# Altium schematic sheet Trottinette_Connexion_PCB.SchDoc(Trottinette_Connexion_PCB)
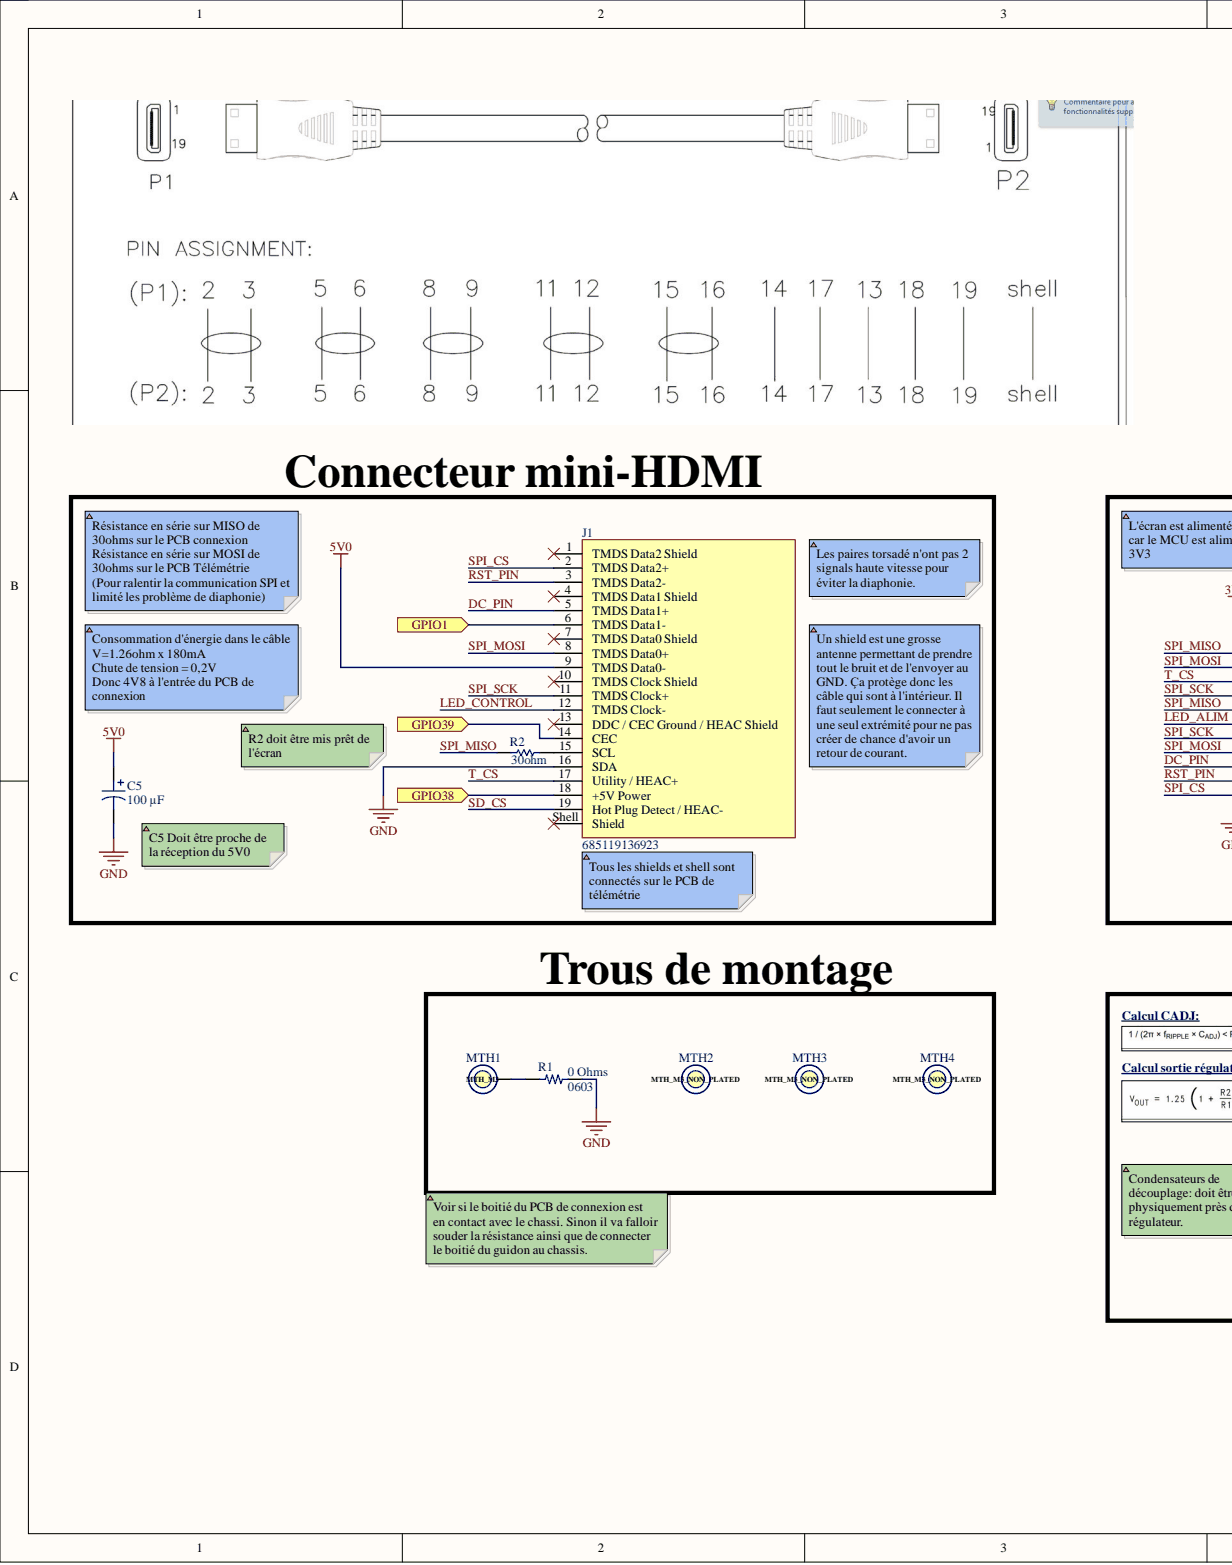
[diagram p0: Trottinette_Connexion_PCB.SchDoc, left]
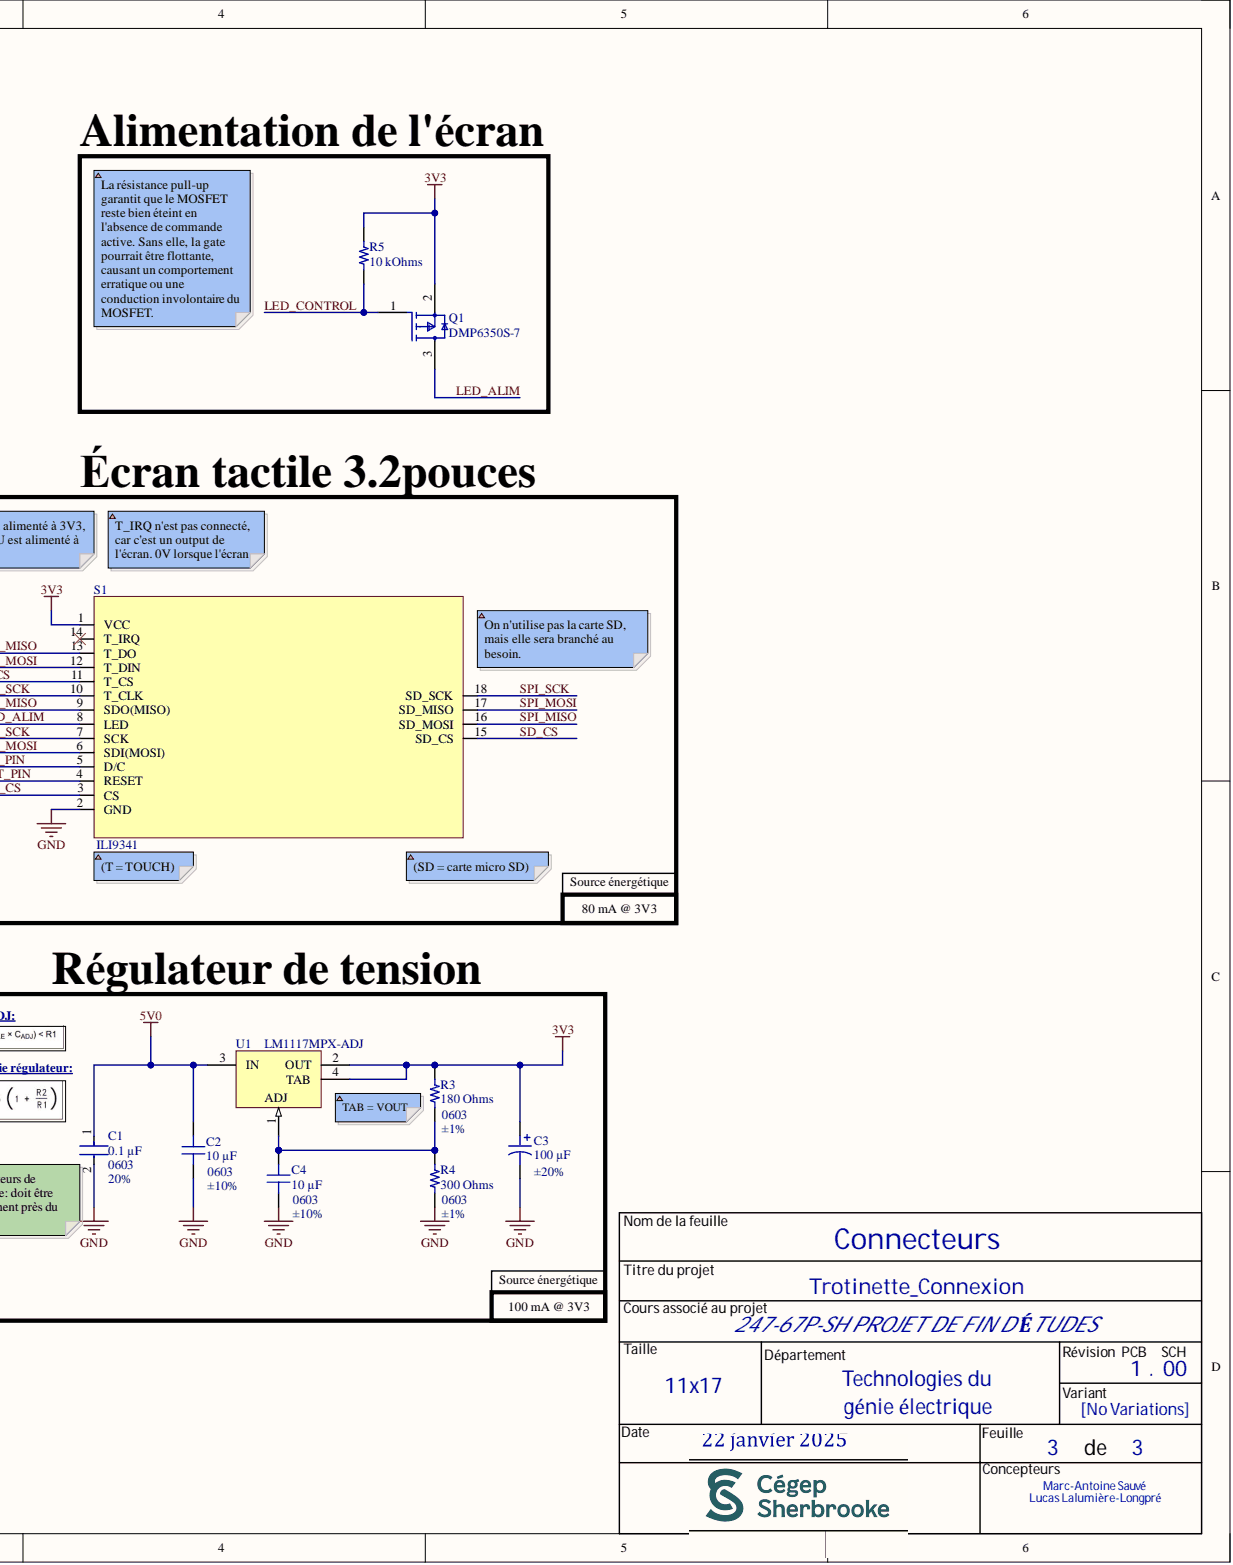
[diagram p1: Trottinette_Connexion_PCB.SchDoc, right]

## Parts
C1  Capacitance: 0.1 µF  Case Code (Imperial): 0603  Tolerance: 20%  [FP-0603-L_1_6_0_15-W_0_81-IPC_B]  (hidden comment: 0603ZC104MAT2A)
C2  10 µF  Device Package: 0603  Tolerance: ±10%  [CAP 0603_1608]
C3  100 µF  Tolerance: ±20%  [CAP ALSMD 6.6MM H6.1MM]
C4  10 µF  Device Package: 0603  Tolerance: ±10%  [CAP 0603_1608]
C5  100 µF  [CAP ALSMD 6.6MM H6.1MM]
J1  685119136923  [685119136923]
MTH1  MTH_M3  [MTH_M3]  (no BOM)
MTH2  MTH_M3_NON_PLATED  [MTH_M3_NON_PLATED]  (no BOM)
MTH3  MTH_M3_NON_PLATED  [MTH_M3_NON_PLATED]  (no BOM)
MTH4  MTH_M3_NON_PLATED  [MTH_M3_NON_PLATED]  (no BOM)
Q1  DMP6350S-7  [FP-SOT23-MFG]
R1  0 Ohms  Supplier Device Package: 0603  [RES 0603_1608]
R2  value 30ohm  [RESC1608X55X30LL15T15]  (hidden comment: ERJ3EKF30R0V)
R3  180 Ohms  Device Package: 0603  Tolerance: ±1%  [RES 0603_1608]
R4  300 Ohms  Supplier Device Package: 0603  Tolerance: ±1%  [RES 0603_1608]
R5  10 kOhms  [RES 0603_1608]
S1  ILI9341  [PCBComponent_1]
U1  LM1117MPX-ADJ  [MP04A_N]

## Ports
GPIO1, GPIO38, GPIO39

## Nets
3V3: C3.1 Q1.2 R3.2 R5.2 S1.1(VCC) U1.2(OUT) U1.4(TAB)
5V0: C1.1 C2.2 C5.1 J1.9(TMDS_Data0-) U1.3(IN)
GND: C1.2 C2.1 C3.2 C4.1 C5.2 J1.16(SDA) R1.2 R4.2 S1.2(GND)
DC_PIN: J1.5(TMDS_Data1+) S1.5(D/C)
GPIO1: J1.6(TMDS_Data1-) ; port GPIO1
GPIO38: J1.18(+5V_Power) ; port GPIO38
GPIO39: J1.14(CEC) ; port GPIO39
LED_ALIM: Q1.3 S1.8(LED)
LED_CONTROL: J1.12(TMDS_Clock-) Q1.1 R5.1
RST_PIN: J1.3(TMDS_Data2-) S1.4(RESET)
SD_CS: J1.19(Hot_Plug_Detect_/_HEAC-) S1.15(SD_CS)
SPI_CS: J1.2(TMDS_Data2+) S1.3(CS)
SPI_MISO: R2.2 S1.9(SDO(MISO)) S1.13(T_DO) S1.16(SD_MOSI)
SPI_MOSI: J1.8(TMDS_Data0+) S1.6(SDI(MOSI)) S1.12(T_DIN) S1.17(SD_MISO)
SPI_SCK: J1.11(TMDS_Clock+) S1.7(SCK) S1.10(T_CLK) S1.18(SD_SCK)
T_CS: J1.17(Utility_/_HEAC+) S1.11(T_CS)
NetC4_2: C4.2 R3.1 R4.1 U1.1
NetJ1_15: J1.15(SCL) R2.1
NetMTH1_1: MTH1.1 R1.1

Not connected: J1 1(TMDS_Data2_Shield) 4(TMDS_Data1_Shield) 7(TMDS_Data0_Shield) 10(TMDS_Clock_Shield) 13(DDC_/_CEC_Ground_/_HEAC_Shield) Shell(Shield); S1 14(T_IRQ)

## Library data of the parts
C1 = CMP-2006-04550-2: General Purpose Ceramic Capacitor, 0603, 100nF, 20%, X7R, 15%, 10V; Case Code (Metric): 1608; Case/Package: 0603; Component Kind: Standard; Construction: Flat; Depth: 0.81mm; Dielectric: X7R; Lead Free: Lead Free; Lead Pitch: 0.014inch; Length: 1.6mm; Library Name: Altium Content Vault; Max Operating Temperature: 125°C; Min Operating Temperature: -55°C; Mount: Surface Mount; Number of Pins: 2; Package Quantity: 4000; Packaging: Tape and Reel; Radiation Hardening: No; RoHS Compliant: Yes; Series: -; Termination: SMD/SMT; Thickness: 0.035inch; Voltage Rating: 10V; Voltage Rating (DC): 10V; Width: 0.032inch
C2 C4 = GRT188R61A106KE13D: CAP CER 10UF 10V X5R 0603; manufacturer: Murata Electronics; Database Table Name: Capacitors - Ceramic - 0603; Applications: Automotive; Capacitance: 10 µF; Component Kind: Standard; componentlink1description: Datasheet; componentlink1url: https://search.murata.co.jp/Ceramy/image/img/A01X/G101/ENG/GRT188R61A106KE13-01.pdf; componentlink2description: DigiKey Link; componentlink2url: https://www.digikey.com/en/products/detail/murata-electronics/GRT188R61A106KE13D/5416733; Features: Derating Recommended; lastupdated: 2/9/2024 8:10:30 PM; Library Name: Celestial Altium Library - altium_library.DbLib; Lifecycle Status: Active; Minimum Order: 1; Mounting Type: Surface Mount, MLCC; Operating Temperature: -55°C ~ 85°C; Package / Case: 0603 (1608 Metric); packaging: TapeAndReel; Part Number: GRT188R61A106KE13D; Part Status: Active; partid: 2522; price: 0.06; Ratings: AEC-Q200; series: GRT; Size / Dimension: 0.063" L x 0.031" W (1.60mm x 0.80mm); Supplier 1: DigiKey; Supplier Part Number 1: 490-12311-1-ND; Temperature Coefficient: X5R; Thickness (Max): 0.037" (0.95mm); Value: 10 µF; Voltage - Rated: 10V
C3 C5 = 10SGV100M6.3X6.1: CAP ALUM 100UF 20% 10V SMD; manufacturer: Rubycon; Database Table Name: Capacitors - Aluminum - Electrolytic SMT; Lifetime @ Temp.: 2000 Hrs @ 105°C; Polarization: Polar; Ripple Current @ High Frequency: 85.2 mA @ 10 kHz; Ripple Current @ Low Frequency: 71 mA @ 120 Hz; Surface Mount Land Size: 0.260" L x 0.260" W (6.60mm x 6.60mm); Applications: Automotive; Capacitance: 100 µF; Component Kind: Standard; componentlink1description: Datasheet; componentlink1url: http://www.rubycon.co.jp/en/catalog/e_pdfs/aluminum/e_sgv.pdf; componentlink2description: DigiKey Link; componentlink2url: https://www.digikey.com/en/products/detail/rubycon/10SGV100M6-3X6-1/3568604; Device Package: Radial, Can - SMD; Height - Seated (Max): 0.240" (6.10mm); lastupdated: 6/3/2024 8:16:10 PM; Library Name: Celestial Altium Library - altium_library.DbLib; Lifecycle Status: Active; Minimum Order: 1; Mounting Type: Surface Mount; Operating Temperature: -55°C ~ 105°C; Package / Case: Radial, Can - SMD; packaging: TapeAndReel; Part Number: 10SGV100M6.3X6.1; Part Status: Active; partid: 8082; price: 0.08; Ratings: AEC-Q200; series: SGV; Size / Dimension: 0.248" Dia (6.30mm); Supplier 1: DigiKey; Supplier Part Number 1: 1189-2392-1-ND; value: 100 µF; Voltage - Rated: 10 V
  C5: Tolerance: ±20%
J1 = CMP-1502-00171-2: NexarPartId: 19499823; Body Material: Brass; Connector Type: HDMI; Contact Resistance: 30mΩ; Current Rating: 500mA; Gender: Receptacle; Insulation Resistance: 100MΩ; Number of Contacts: 19; Operating Supply Voltage: 40V; Component Kind: Standard; Contact Material: Gold; Contact Plating: Gold; Features: Shielded; Height: 3.45mm; Lead Free: Lead Free; Library Name: Altium Content Vault; Max Operating Temperature: 85°C; Min Operating Temperature: -25°C; Mount: Horizontal, Surface Mount; Number of Pins: 19; Number of Positions: 19; Orientation: Horizontal; Packaging: Tape and Reel; REACH SVHC: Unknown; RoHS: Compliant; Termination: SMD/SMT; Voltage Rating: 40V
MTH1 = CMP-006-000008-2: MTH - MOUNTING HOLE M3 PLATED - M3; Assembly Type: NONE; Component Type: Standard (No BOM); Design Item ID: MTH_M3; HelpURL: https://littlemachineshop.com/images/gallery/PDF/TapDrillSizes.pdf; Package: M3; Pin Count: 1; Library Name: Cegep de Sherbrooke; Source: CEGEP_SHERBROOKE_TGE_LIBRARY.SchLib
MTH2-MTH4 = CMP-006-000010-2: MTH - MOUNTING HOLE M3 NON-PLATED - M3; Assembly Type: NONE; Component Type: Standard (No BOM); Design Item ID: MTH_M3_NON_PLATED; HelpURL: https://littlemachineshop.com/images/gallery/PDF/TapDrillSizes.pdf; Package: M3; Pin Count: 0; Library Name: Cegep de Sherbrooke; Source: CEGEP_SHERBROOKE_TGE_LIBRARY.SchLib
Q1 = CMP-12801-000018-2: MOSFET P-CHA 60V 720MW SOT23; Continuous Drain Current (ID): 1.5A; Input Capacitance: 206pF; Rds On Max: 350mR; Case/Package: TO-236-3; Component Kind: Standard; Drain to Source Voltage (Vdss): 60V; Library Name: Altium Content Vault; Max Operating Temperature: 150°C; Max Power Dissipation: 720mW; Min Operating Temperature: -55°C; Mount: Surface Mount; Packaging: Tape and Reel; RoHS Compliant: Yes
R1 = CR0603AJ/-000EAS: RESA-AS 0603 0R JUMPER 100MW; Manufacturer: Bourns Inc.; Database Table Name: Resistors - Surface Mount - 0603; Power (Watts): 0.1W, 1/10W; Component Kind: Standard; ComponentLink1Description: Datasheet; ComponentLink1URL: //media.digikey.com/pdf/Data%20Sheets/Bourns%20PDFs/CRyyyyA-AS_Model_DS.pdf; ComponentLink2Description: DigiKey Link; ComponentLink2URL: https://www.digikey.com/en/products/detail/bourns-inc/CR0603AJ-000EAS/10240122; Composition: Thick Film; Device Package: 0603 (1608 Metric); Features: Anti-Sulfur, Automotive AEC-Q200, Moisture Resistant; Height - Seated (Max): 0.022" (0.55mm); LastUpdated: 9/18/2023 7:33:24 PM; Library Name: Celestial Altium Library - altium_library.DbLib; Lifecycle Status: Active; Minimum Order: 1; Number of Terminations: 2; Operating Temperature: -55°C ~ 155°C; Package / Case: 0603 (1608 Metric); Packaging: TapeAndReel; Part Number: CR0603AJ/-000EAS; Part Status: Active; PartId: 24557; Price: 0.01; Ratings: AEC-Q200; Resistance: 0 Ohms; Series: CR0603A-AS; Size / Dimension: 0.063" L x 0.031" W (1.60mm x 0.80mm); Supplier 1: DigiKey; Supplier Part Number 1: CR0603AJ/-000EASCT-ND; Tolerance: Jumper; Value: 0 Ohms
R2 = CMP-2000-00423-1: 30Ohms; Manufacturer: Panasonic; Tolerance: 1%; Component Kind: Standard; Library Name: Altium Content Vault; Part Number: ERJ3EKF30R0V
R3 = RNCP0603FTD180R: RES 180 OHM 1% 1/8W 0603; Manufacturer: Stackpole Electronics Inc; Database Table Name: Resistors - Surface Mount - 0603; Power (Watts): 0.125W, 1/8W; Temperature Coefficient: ±100ppm/°C; Component Kind: Standard; ComponentLink1Description: Datasheet; ComponentLink1URL: https://www.seielect.com/catalog/sei-rncp.pdf; ComponentLink2Description: DigiKey Link; ComponentLink2URL: https://www.digikey.com/en/products/detail/stackpole-electronics-inc/RNCP0603FTD180R/2240089; Composition: Thin Film; Features: Anti-Sulfur; Height - Seated (Max): 0.022" (0.55mm); LastUpdated: 2/9/2024 8:43:33 PM; Library Name: Celestial Altium Library - altium_library.DbLib; Lifecycle Status: Active; Minimum Order: 1; Number of Terminations: 2; Operating Temperature: -55°C ~ 155°C; Package / Case: 0603 (1608 Metric); Packaging: TapeAndReel; Part Number: RNCP0603FTD180R; Part Status: Active; PartId: 5708; Price: 0.01; Resistance: 180 Ohms; Series: RNCP; Size / Dimension: 0.061" L x 0.031" W (1.55mm x 0.80mm); Supplier 1: DigiKey; Supplier Device Package: 0603; Supplier Part Number 1: RNCP0603FTD180RCT-ND; Value: 180 Ohms
R4 = CRCW0603300RFKEA: RES SMD 300 OHM 1% 1/10W 0603; Manufacturer: Vishay Dale; Database Table Name: Resistors - Surface Mount - 0603; Power (Watts): 0.1W, 1/10W; Temperature Coefficient: ±100ppm/°C; Component Kind: Standard; ComponentLink1Description: Datasheet; ComponentLink1URL: http://www.vishay.com/docs/20035/dcrcwe3.pdf; ComponentLink2Description: DigiKey Link; ComponentLink2URL: https://www.digikey.com/en/products/detail/vishay-dale/CRCW0603300RFKEA/1174606; Composition: Thick Film; Device Package: 0603 (1608 Metric); Features: Automotive AEC-Q200; Height - Seated (Max): 0.020" (0.50mm); LastUpdated: 2/9/2024 8:43:25 PM; Library Name: Celestial Altium Library - altium_library.DbLib; Lifecycle Status: Active; Minimum Order: 1; Number of Terminations: 2; Operating Temperature: -55°C ~ 155°C; Package / Case: 0603 (1608 Metric); Packaging: TapeAndReel; Part Number: CRCW0603300RFKEA; Part Status: Active; PartId: 7780; Price: 0.01; Ratings: AEC-Q200; Resistance: 300 Ohms; Series: CRCW; Size / Dimension: 0.061" L x 0.033" W (1.55mm x 0.85mm); Supplier 1: DigiKey; Supplier Part Number 1: 541-300HCT-ND; Value: 300 Ohms
R5 = RNCS0603BKE10K0: RES 10K OHM 0.1% 1/16W 0603; Manufacturer: Stackpole Electronics Inc; Database Table Name: Resistors - Surface Mount - 0603; Power (Watts): 0.063W, 1/16W; Temperature Coefficient: ±25ppm/°C; Component Kind: Standard; ComponentLink1Description: Datasheet; ComponentLink1URL: https://www.seielect.com/catalog/sei-rncs_rnch.pdf; ComponentLink2Description: DigiKey Link; ComponentLink2URL: https://www.digikey.com/en/products/detail/stackpole-electronics-inc/RNCS0603BKE10K0/1708836; Composition: Thin Film; Device Package: 0603 (1608 Metric); Features: Anti-Corrosive, Automotive AEC-Q200, Moisture Resistant; Height - Seated (Max): 0.022" (0.55mm); LastUpdated: 2024-02-09 20:43:37; Library Name: Celestial Altium Library - altium_library.DbLib; Lifecycle Status: Active; Minimum Order: 1; Number of Terminations: 2; Operating Temperature: -55°C ~ 155°C; Package / Case: 0603 (1608 Metric); Packaging: TapeAndReel; Part Number: RNCS0603BKE10K0; Part Status: Active; PartId: 4838; Price: 0.06; Ratings: AEC-Q200; Resistance: 10 kOhms; Series: RNCS; Size / Dimension: 0.061" L x 0.031" W (1.55mm x 0.80mm); Supplier 1: DigiKey; Supplier Device Package: 0603; Supplier Part Number 1: RNCS0603BKE10K0CT-ND; Tolerance: ±0.1%; Value: 10 kOhms
S1 = ILI9341: 3.2" 240x320 TFT LCD ILI9341; Cryo: UNKNOWN; GRAMS Lib Spec: 3.2; Component Kind: Standard; Library Name: ILI9341.SchLib; Magnetic Material: UNKNOWN; Solder Paste Type: UNKNOWN; Stencil Thickness (Recommended): UNKNOWN
U1 = CMP-0062-01642-3: 800mA Low-Dropout Linear Regulator, 4-pin SOT-223; Manufacturer: National Semiconductor; CompType: LDO IC; High-Reliability: Y; PackageTopMarking: XYTT*N03A; PowerWise: N; Code_IPC: SOT223-4; Code_JEDEC: TO-261-AA; Component Kind: Standard; ComponentLink1Description: Manufacturer URL; ComponentLink1URL: http://www.national.com/; ComponentLink2Description: LM1117 Datasheet; ComponentLink2URL: http://www.national.com/ds/LM/LM1117.pdf; ComponentLink3Description: LM1117 Web Page; ComponentLink3URL: http://www.national.com/pf/LM/LM1117.html; ComponentLink4Description: MP04A Package Page; ComponentLink4URL: http://www.national.com/packaging/folders/mp04a.html; DatasheetVersion: Apr-2006; Library Name: Altium Content Vault; PackageDescription: Molded SOT-223, 6.50 x 3.56 x 1.6mm Body, 4 Lead, 2.3mm Pitch; PackageReference: MP04A; PackageVersion: revB, Apr-2003; PartNumber: LM1117MPX-ADJ; PinCount: 4; RevisionNote: SCH symbol layout adjusted; RoHS: N
